# Altium schematic sheet ISL6236A_DEMO.SchDoc (continued)

## Library data of the parts
C1 = CC1206KKX5R9BB475: Ceramic Capacitor, Ceramic, 50V, 10% +Tol, 10% -Tol, X5R, 15% TC, 4.7uF, Surface Mount, 1206; Manufacturer: Yageo Group; Value: 4.7µF; Case Code (Imperial): 1206; Case Code (Metric): 3216; Case/Package: 1206; Category: Ceramic Capacitors; China RoHS: Compliant; Component Kind: Standard; ComponentLink1Description: https://datasheet.octopart.com:443/CC1206KKX5R9BB475-Yageo-datasheet-170350636.pdf?src-supplier=IHS; ComponentLink1URL: https://datasheet.octopart.com:443/CC1206KKX5R9BB475-Yageo-datasheet-170350636.pdf?src-supplier=IHS; ComponentLink2Description: https://datasheet.octopart.com:443/CC1206KKX5R9BB475-Yageo-datasheet-116051370.pdf?src-supplier=Upverter; ComponentLink2URL: https://datasheet.octopart.com:443/CC1206KKX5R9BB475-Yageo-datasheet-116051370.pdf?src-supplier=Upverter; ComponentLink3Description: https://datasheet.octopart.com:443/CC1206KKX5R9BB475-Yageo-datasheet-82288757.pdf?src-supplier=Upverter; ComponentLink3URL: https://datasheet.octopart.com:443/CC1206KKX5R9BB475-Yageo-datasheet-82288757.pdf?src-supplier=Upverter; ComponentLink4Description: https://datasheet.octopart.com:443/CC1206KKX5R9BB475-Yageo-datasheet-68297942.pdf?src-supplier=Upverter; ComponentLink4URL: https://datasheet.octopart.com:443/CC1206KKX5R9BB475-Yageo-datasheet-68297942.pdf?src-supplier=Upverter; ComponentLink5Description: https://datasheet.octopart.com:443/CC1206KKX5R9BB475-Yageo-datasheet-181970657.pdf?src-supplier=Farnell; ComponentLink5URL: https://datasheet.octopart.com:443/CC1206KKX5R9BB475-Yageo-datasheet-181970657.pdf?src-supplier=Farnell; ComponentLink6Description: https://datasheet.octopart.com:443/CC1206KKX5R9BB475-Yageo-datasheet-181970654.pdf?src-supplier=Farnell; ComponentLink6URL: https://datasheet.octopart.com:443/CC1206KKX5R9BB475-Yageo-datasheet-181970654.pdf?src-supplier=Farnell; ComponentLink7Description: https://datasheet.octopart.com:443/CC1206KKX5R9BB475-Yageo-datasheet-145016144.pdf?src-supplier=ODG+(Origin+Data+Global); ComponentLink7URL: https://datasheet.octopart.com:443/CC1206KKX5R9BB475-Yageo-datasheet-145016144.pdf?src-supplier=ODG+(Origin+Data+Global); ComponentLink8Description: https://datasheet.octopart.com:443/CC1206KKX5R9BB475-Yageo-datasheet-158238148.pdf?src-supplier=Newark; ComponentLink8URL: https://datasheet.octopart.com:443/CC1206KKX5R9BB475-Yageo-datasheet-158238148.pdf?src-supplier=Newark; ComponentLink9Description: https://datasheet.octopart.com/CC1206KKX5R9BB475-Yageo-datasheet-86397281.pdf; ComponentLink9URL: https://datasheet.octopart.com/CC1206KKX5R9BB475-Yageo-datasheet-86397281.pdf; ComponentLink10Description: http://sigma.octopart.com:80/rohs_statement/Yageo-company-2.pdf?src-supplier=Compliance+Statements; ComponentLink10URL: http://sigma.octopart.com:80/rohs_statement/Yageo-company-2.pdf?src-supplier=Compliance+Statements; ComponentLink11Description: http://sigma.octopart.com:80/conflict_mineral_statement/Yageo-company-3.pdf?src-supplier=Compliance+Statements; ComponentLink11URL: http://sigma.octopart.com:80/conflict_mineral_statement/Yageo-company-3.pdf?src-supplier=Compliance+Statements; Composition: Ceramic; Dielectric: X5R; Dielectric Material: Ceramic; Halogen Free: Halogen Free; Height: 1.6mm; Lead Free: Lead Free; Length: 3.2mm; Library Name: ISL6236A_DEMO.SchLib; Lifecycle Status: Production; Manufacturer Part Number: CC1206KKX5R9BB475; Material: Ceramic; Max Operating Temperature: 85°C; Min Operating Temperature: -55°C; Mounting Technology: Surface Mount; Number of Terminals: 2; Packaging: Tape & Reel (TR); Pins: 2; Pricing: 1=0.32, 10=0.142, 50=0.142, 100=0.116, 1000=0.098, 10000=0.072 (USD); REACH SVHC: Yes; RoHS: Compliant; Schedule B: 8532240020, 8532240020|8532240020|8532240020|8532240020|8532240020; Stock: 5467; Supplier: Mouser; Supplier Part Number: 603-CC206KKX5R9BB475; Termination: SMD/SMT; Thickness: 1.8034mm; Tolerance: 10%; Voltage Rating: 50V; Voltage Rating (DC): 50V; Weight: 16.187578mg; Width: 1.6mm
C2-C4 = 08055C105K4Z2A: Cap Ceramic 1uF 50V X7R 10% Pad SMD 0805 FlexiTerm 125C Automotive T/R; Manufacturer: KYOCERA AVX; Value: 1µF; Case/Package: 0805; Category: Ceramic Capacitors; China RoHS: Compliant; Component Kind: Standard; ComponentLink1Description: https://datasheet.octopart.com:443/08055C105K4Z2A-KYOCERA-AVX-datasheet-172544266.pdf?src-supplier=IHS; ComponentLink1URL: https://datasheet.octopart.com:443/08055C105K4Z2A-KYOCERA-AVX-datasheet-172544266.pdf?src-supplier=IHS; ComponentLink2Description: https://sigma.octopart.com:443/147443767/technical_drawing/AVX-08055C105K4Z2A.pdf?src-supplier=Upverter; ComponentLink2URL: https://sigma.octopart.com:443/147443767/technical_drawing/AVX-08055C105K4Z2A.pdf?src-supplier=Upverter; ComponentLink3Description: https://datasheet.octopart.com:443/08055C105K4Z2A-KYOCERA-AVX-datasheet-140258758.pdf?src-supplier=Farnell; ComponentLink3URL: https://datasheet.octopart.com:443/08055C105K4Z2A-KYOCERA-AVX-datasheet-140258758.pdf?src-supplier=Farnell; ComponentLink4Description: https://datasheet.octopart.com:443/08055C105K4Z2A-AVX-datasheet-78690206.pdf?src-supplier=element14+APAC; ComponentLink4URL: https://datasheet.octopart.com:443/08055C105K4Z2A-AVX-datasheet-78690206.pdf?src-supplier=element14+APAC; ComponentLink5Description: https://datasheet.octopart.com:443/08055C105K4Z2A-AVX-datasheet-62336669.pdf?src-supplier=element14+APAC; ComponentLink5URL: https://datasheet.octopart.com:443/08055C105K4Z2A-AVX-datasheet-62336669.pdf?src-supplier=element14+APAC; ComponentLink6Description: https://datasheet.octopart.com:443/08055C105K4Z2A-AVX-datasheet-42544723.pdf?src-supplier=element14+APAC; ComponentLink6URL: https://datasheet.octopart.com:443/08055C105K4Z2A-AVX-datasheet-42544723.pdf?src-supplier=element14+APAC; ComponentLink7Description: https://datasheet.octopart.com:443/08055C105K4Z2A-AVX-datasheet-158242088.pdf?src-supplier=Amar+Radio+Corporation; ComponentLink7URL: https://datasheet.octopart.com:443/08055C105K4Z2A-AVX-datasheet-158242088.pdf?src-supplier=Amar+Radio+Corporation; ComponentLink8Description: https://datasheet.octopart.com:443/08055C105K4Z2A-KYOCERA-AVX-datasheet-50865432.pdf?src-supplier=Future+Electronics; ComponentLink8URL: https://datasheet.octopart.com:443/08055C105K4Z2A-KYOCERA-AVX-datasheet-50865432.pdf?src-supplier=Future+Electronics; ComponentLink9Description: https://datasheet.octopart.com/08055C105K4Z2A-KYOCERA-AVX-datasheet-13516023.pdf; ComponentLink9URL: https://datasheet.octopart.com/08055C105K4Z2A-KYOCERA-AVX-datasheet-13516023.pdf; ComponentLink10Description: http://sigma.octopart.com:80/reach_statement/AVX-company-19.pdf?src-supplier=Compliance+Statements; ComponentLink10URL: http://sigma.octopart.com:80/reach_statement/AVX-company-19.pdf?src-supplier=Compliance+Statements; ComponentLink11Description: http://sigma.octopart.com:80/conflict_mineral_statement/AVX-company-34.pdf?src-supplier=Compliance+Statements; ComponentLink11URL: http://sigma.octopart.com:80/conflict_mineral_statement/AVX-company-34.pdf?src-supplier=Compliance+Statements; Contact Plating: Tin; Dielectric: X7R; Dielectric Material: Ceramic; Height: 1.4mm; Length: 2.01mm; Library Name: ISL6236A_DEMO.SchLib; Lifecycle Status: Production; Manufacturer Part Number: 08055C105K4Z2A; Material: Ceramic; Max Operating Temperature: 125°C; Min Operating Temperature: -55°C; Mounting Technology: Surface Mount; Number of Terminals: 2; Pricing: 1=0.33, 10=0.144, 50=0.144, 100=0.128, 1000=0.092, 10000=0.067 (USD); REACH SVHC: Yes; RoHS: Compliant; Schedule B: 8532240020, 8532240020|8532240020|8532240020|8532240020|8532240020|8532240020|8532240020|8532240020|8532240020|8532240020|8532240020|8532240020|8532240020|8532240020|8532240020|8532240020|8532240020|8532240020|8532240020|8532240020|8532240020|8532240020|8532240020|85; Stock: 12042; Supplier: Mouser; Supplier Part Number: 581-08055C105K4Z2A; Termination: Wraparound; Tolerance: 10%; Voltage Rating (DC): 50V; Width: 1.25mm
  C3: Voltage Rating: 50V
C5 C6 = C3225X5R1H106K250AB: 50V 10uF X5R 卤10% 1210 Multilayer Ceramic Capacitors MLCC - SMD/SMT ROHS; Manufacturer: TDK; Manufacturer Lifecycle Status: PRODUCTION; Tolerance: 10%; Value: 10µF; Case Code (Imperial): 1210; Case Code (Metric): 3225; Case/Package: 1210; Category: Ceramic Capacitors; China RoHS: Compliant; Component Kind: Standard; ComponentLink1Description: https://datasheet.octopart.com:443/C3225X5R1H106K250AB-TDK-datasheet-175423548.pdf?src-supplier=TME; ComponentLink1URL: https://datasheet.octopart.com:443/C3225X5R1H106K250AB-TDK-datasheet-175423548.pdf?src-supplier=TME; ComponentLink2Description: https://datasheet.octopart.com:443/C3225X5R1H106K250AB-TDK-datasheet-182135025.pdf?src-supplier=TME; ComponentLink2URL: https://datasheet.octopart.com:443/C3225X5R1H106K250AB-TDK-datasheet-182135025.pdf?src-supplier=TME; ComponentLink3Description: https://datasheet.octopart.com:443/C3225X5R1H106K250AB-TDK-datasheet-159617022.pdf?src-supplier=TME; ComponentLink3URL: https://datasheet.octopart.com:443/C3225X5R1H106K250AB-TDK-datasheet-159617022.pdf?src-supplier=TME; ComponentLink4Description: https://sigma.octopart.com:443/138898468/technical_drawing/TDK-C3225X5R1H106K250AB.pdf?src-supplier=Upverter; ComponentLink4URL: https://sigma.octopart.com:443/138898468/technical_drawing/TDK-C3225X5R1H106K250AB.pdf?src-supplier=Upverter; ComponentLink5Description: https://datasheet.octopart.com:443/C3225X5R1H106K250AB-TDK-datasheet-151546523.pdf?src-supplier=ODG+(Origin+Data+Global); ComponentLink5URL: https://datasheet.octopart.com:443/C3225X5R1H106K250AB-TDK-datasheet-151546523.pdf?src-supplier=ODG+(Origin+Data+Global); ComponentLink6Description: https://datasheet.octopart.com:443/C3225X5R1H106K250AB-TDK-datasheet-82475248.pdf?src-supplier=Farnell; ComponentLink6URL: https://datasheet.octopart.com:443/C3225X5R1H106K250AB-TDK-datasheet-82475248.pdf?src-supplier=Farnell; ComponentLink7Description: https://datasheet.octopart.com/C3225X5R1H106K250AB-TDK-datasheet-41194876.pdf; ComponentLink7URL: https://datasheet.octopart.com/C3225X5R1H106K250AB-TDK-datasheet-41194876.pdf; ComponentLink8Description: https://datasheet.octopart.com:443/C3225X5R1H106K250AB-TDK-datasheet-25352837.pdf?src-supplier=Future+Electronics; ComponentLink8URL: https://datasheet.octopart.com:443/C3225X5R1H106K250AB-TDK-datasheet-25352837.pdf?src-supplier=Future+Electronics; ComponentLink9Description: https://datasheet.octopart.com:443/C3225X5R1H106K250AB-TDK-datasheet-23766614.pdf?src-supplier=Future+Electronics; ComponentLink9URL: https://datasheet.octopart.com:443/C3225X5R1H106K250AB-TDK-datasheet-23766614.pdf?src-supplier=Future+Electronics; ComponentLink10Description: https://datasheet.octopart.com:443/C3225X5R1H106K250AB-TDK-datasheet-10921857.pdf?src-supplier=Newark; ComponentLink10URL: https://datasheet.octopart.com:443/C3225X5R1H106K250AB-TDK-datasheet-10921857.pdf?src-supplier=Newark; Composition: Ceramic; Depth: 2.5mm; Dielectric: X5R; Dielectric Material: Ceramic; Height: 2.5mm; Lead Free: Lead Free; Length: 3.2mm; Library Name: ISL6236A_DEMO.SchLib; Lifecycle Status: Production; Manufacturer Part Number: C3225X5R1H106K250AB; Material: Ceramic; Max Length: 3.6mm; Max Operating Temperature: 85°C; Max Width: 2.8mm; Min Length: 2.8mm; Min Operating Temperature: -55°C; Min Width: 2.2mm; Mounting Technology: Surface Mount; Number of Terminals: 2; Packaging: Digi-Reel®; Pricing: 1=0.94, 10=0.421, 50=0.421, 100=0.395, 1000=0.297, 10000=0.286 (USD); REACH SVHC: Yes; RoHS: Compliant; Schedule B: 8532240020, 8532240020|8532240020|8532240020|8532240020|8532240020; Stock: 64452; Supplier: Mouser; Supplier Part Number: 810-C3225X5R1H106K; Termination: SMD/SMT; Voltage Rating: 50V; Voltage Rating (DC): 50V; Weight: 15.989131mg; Width: 2.5mm
C7 C12 = 08055C104JAT2A: 0805 100nF 50 V ±5 % Tolerance X7R Surface Mount Multilayer Ceramic Capacitor; Manufacturer: KYOCERA AVX; Dissipation Factor: 2.5%; Insulation Resistance: 100GΩ; Tolerance: 5%; Voltage: 50V; Case Code (Imperial): 0805; Case/Package: 0805; Category: Ceramic Capacitors; China RoHS: Compliant; Component Kind: Standard; ComponentLink1Description: https://datasheet.octopart.com:443/08055C104JAT2A-KYOCERA-AVX-datasheet-170560559.pdf?src-supplier=IHS; ComponentLink1URL: https://datasheet.octopart.com:443/08055C104JAT2A-KYOCERA-AVX-datasheet-170560559.pdf?src-supplier=IHS; ComponentLink2Description: https://sigma.octopart.com:443/147443767/technical_drawing/AVX-08055C104JAT2A.pdf?src-supplier=Upverter; ComponentLink2URL: https://sigma.octopart.com:443/147443767/technical_drawing/AVX-08055C104JAT2A.pdf?src-supplier=Upverter; ComponentLink3Description: https://datasheet.octopart.com:443/08055C104JAT2A-AVX-datasheet-12509786.pdf?src-supplier=Farnell; ComponentLink3URL: https://datasheet.octopart.com:443/08055C104JAT2A-AVX-datasheet-12509786.pdf?src-supplier=Farnell; ComponentLink4Description: https://datasheet.octopart.com:443/08055C104JAT2A-AVX-datasheet-12587061.pdf?src-supplier=Farnell; ComponentLink4URL: https://datasheet.octopart.com:443/08055C104JAT2A-AVX-datasheet-12587061.pdf?src-supplier=Farnell; ComponentLink5Description: https://datasheet.octopart.com:443/08055C104JAT2A-AVX-datasheet-8113618.pdf?src-supplier=Newark; ComponentLink5URL: https://datasheet.octopart.com:443/08055C104JAT2A-AVX-datasheet-8113618.pdf?src-supplier=Newark; ComponentLink6Description: https://datasheet.octopart.com:443/08055C104JAT2A-KYOCERA-AVX-datasheet-158983632.pdf?src-supplier=Newark; ComponentLink6URL: https://datasheet.octopart.com:443/08055C104JAT2A-KYOCERA-AVX-datasheet-158983632.pdf?src-supplier=Newark; ComponentLink7Description: https://datasheet.octopart.com:443/08055C104JAT2A-AVX-datasheet-78690206.pdf?src-supplier=Newark; ComponentLink7URL: https://datasheet.octopart.com:443/08055C104JAT2A-AVX-datasheet-78690206.pdf?src-supplier=Newark; ComponentLink8Description: https://datasheet.octopart.com:443/08055C104JAT2A-KYOCERA-AVX-datasheet-65931687.pdf?src-supplier=Newark; ComponentLink8URL: https://datasheet.octopart.com:443/08055C104JAT2A-KYOCERA-AVX-datasheet-65931687.pdf?src-supplier=Newark; ComponentLink9Description: https://datasheet.octopart.com:443/08055C-104JAT2A-AVX-datasheet-26446220.pdf?src-supplier=Newark; ComponentLink9URL: https://datasheet.octopart.com:443/08055C-104JAT2A-AVX-datasheet-26446220.pdf?src-supplier=Newark; ComponentLink10Description: https://datasheet.octopart.com:443/08055C104JAT2A-AVX-datasheet-5313617.pdf?src-supplier=Newark; ComponentLink10URL: https://datasheet.octopart.com:443/08055C104JAT2A-AVX-datasheet-5313617.pdf?src-supplier=Newark; ComponentLink11Description: https://datasheet.octopart.com:443/08055C104JAT2A-AVX-datasheet-167033.pdf?src-supplier=Newark; ComponentLink11URL: https://datasheet.octopart.com:443/08055C104JAT2A-AVX-datasheet-167033.pdf?src-supplier=Newark; ComponentLink12Description: https://datasheet.octopart.com:443/08055C104JAT2A-AVX---Kyocera-datasheet-8446237.pdf?src-supplier=TME; ComponentLink12URL: https://datasheet.octopart.com:443/08055C104JAT2A-AVX---Kyocera-datasheet-8446237.pdf?src-supplier=TME; ComponentLink13Description: https://datasheet.octopart.com:443/08055C104JAT2A-KYOCERA-AVX-datasheet-156093841.pdf?src-supplier=TME; ComponentLink13URL: https://datasheet.octopart.com:443/08055C104JAT2A-KYOCERA-AVX-datasheet-156093841.pdf?src-supplier=TME; ComponentLink14Description: https://datasheet.octopart.com:443/08055C104JAT2A-AVX-datasheet-166051239.pdf?src-supplier=Amar+Radio+Corporation; ComponentLink14URL: https://datasheet.octopart.com:443/08055C104JAT2A-AVX-datasheet-166051239.pdf?src-supplier=Amar+Radio+Corporation; ComponentLink15Description: https://datasheet.octopart.com:443/08055C104JAT2A-AVX-datasheet-50865064.pdf?src-supplier=Future+Electronics; ComponentLink15URL: https://datasheet.octopart.com:443/08055C104JAT2A-AVX-datasheet-50865064.pdf?src-supplier=Future+Electronics; ComponentLink16Description: https://datasheet.octopart.com:443/08055C104JAT2A-KYOCERA-AVX-datasheet-50865067.pdf?src-supplier=Future+Electronics; ComponentLink16URL: https://datasheet.octopart.com:443/08055C104JAT2A-KYOCERA-AVX-datasheet-50865067.pdf?src-supplier=Future+Electronics; ComponentLink17Description: https://datasheet.octopart.com:443/08055C104JAT2A-AVX-datasheet-12340990.pdf?src-supplier=Future+Electronics; ComponentLink17URL: https://datasheet.octopart.com:443/08055C104JAT2A-AVX-datasheet-12340990.pdf?src-supplier=Future+Electronics; ComponentLink18Description: https://datasheet.octopart.com:443/08055C104JAT2A-AVX-datasheet-8448153.pdf?src-supplier=Future+Electronics; ComponentLink18URL: https://datasheet.octopart.com:443/08055C104JAT2A-AVX-datasheet-8448153.pdf?src-supplier=Future+Electronics; ComponentLink19Description: https://datasheet.octopart.com:443/08055C104JAT2A-AVX-datasheet-5314571.pdf?src-supplier=Future+Electronics; ComponentLink19URL: https://datasheet.octopart.com:443/08055C104JAT2A-AVX-datasheet-5314571.pdf?src-supplier=Future+Electronics; ComponentLink20Description: https://datasheet.octopart.com/08055C104JAT2A-AVX---Kyocera-datasheet-13516023.pdf; ComponentLink20URL: https://datasheet.octopart.com/08055C104JAT2A-AVX---Kyocera-datasheet-13516023.pdf; ComponentLink21Description: https://datasheet.octopart.com/Commercial-Surface-Mount-Chips---X7R-Dielectric-AVX-datasheet-family-100.pdf; ComponentLink21URL: https://datasheet.octopart.com/Commercial-Surface-Mount-Chips---X7R-Dielectric-AVX-datasheet-family-100.pdf; ComponentLink22Description: https://datasheet.octopart.com:443/08055C104JAT2A-AVX-datasheet-5377487.pdf?src-supplier=Garrett; ComponentLink22URL: https://datasheet.octopart.com:443/08055C104JAT2A-AVX-datasheet-5377487.pdf?src-supplier=Garrett; ComponentLink23Description: https://datasheet.octopart.com:443/08055C104JAT2A-AVX-datasheet-5383.pdf?src-supplier=Garrett; ComponentLink23URL: https://datasheet.octopart.com:443/08055C104JAT2A-AVX-datasheet-5383.pdf?src-supplier=Garrett; ComponentLink24Description: https://datasheet.octopart.com:443/08055C104JAT2A-AVX-datasheet-148183.pdf?src-supplier=Garrett; ComponentLink24URL: https://datasheet.octopart.com:443/08055C104JAT2A-AVX-datasheet-148183.pdf?src-supplier=Garrett; ComponentLink25Description: https://datasheet.octopart.com:443/08055C104JAT2A-AVX-datasheet-17703057.pdf?src-supplier=Jameco; ComponentLink25URL: https://datasheet.octopart.com:443/08055C104JAT2A-AVX-datasheet-17703057.pdf?src-supplier=Jameco; ComponentLink26Description: https://datasheet.octopart.com:443/08055C104JAT2A-AVX-datasheet-12134.pdf?src-supplier=DigiKey; ComponentLink26URL: https://datasheet.octopart.com:443/08055C104JAT2A-AVX-datasheet-12134.pdf?src-supplier=DigiKey; ComponentLink27Description: https://datasheet.octopart.com:443/08055C104JAT2A-AVX-datasheet-4352.pdf?src-supplier=RS+(Formerly+Allied+Electronics); ComponentLink27URL: https://datasheet.octopart.com:443/08055C104JAT2A-AVX-datasheet-4352.pdf?src-supplier=RS+(Formerly+Allied+Electronics); ComponentLink28Description: https://datasheet.octopart.com:443/08055C104JAT2A-AVX-datasheet-111406.pdf?src-supplier=Sierra+IC; ComponentLink28URL: https://datasheet.octopart.com:443/08055C104JAT2A-AVX-datasheet-111406.pdf?src-supplier=Sierra+IC; ComponentLink29Description: http://sigma.octopart.com:80/reach_statement/AVX-company-19.pdf?src-supplier=Compliance+Statements; ComponentLink29URL: http://sigma.octopart.com:80/reach_statement/AVX-company-19.pdf?src-supplier=Compliance+Statements; ComponentLink30Description: http://sigma.octopart.com:80/conflict_mineral_statement/AVX-company-34.pdf?src-supplier=Compliance+Statements; ComponentLink30URL: http://sigma.octopart.com:80/conflict_mineral_statement/AVX-company-34.pdf?src-supplier=Compliance+Statements; Contact Plating: Tin; Depth: 1.25mm; Dielectric: X7R; Dielectric Material: Ceramic; Height: 940µm; Lead Free: Lead Free; Length: 2.01mm; Library Name: ISL6236A_DEMO.SchLib; Lifecycle Status: Production; Manufacturer Part Number: 08055C104JAT2A; Material: Ceramic; Max Operating Temperature: 125°C; Min Operating Temperature: -55°C; Mounting Technology: Surface Mount; Number of Terminals: 2; Packaging: Cut Tape; Pins: 2; Pricing: 1=0.11, 10=0.067, 50=0.067, 100=0.054, 1000=0.048, 10000=0.022 (USD); Radiation Hardening: No; REACH SVHC: Yes; RoHS: Compliant; Schedule B: 8532240020; Stock: 196751; Supplier: Mouser; Supplier Part Number: 581-08055C104J; Termination: SMD/SMT; Thickness: 939.8µm; Value: 100nF; Voltage Rating: 50V; Voltage Rating (DC): 50V; Width: 1.25mm
C8 = 08055C224KAZ2A: Cap Ceramic 0.22uF 50V X7R 10% SMD 0805 FlexiTerm 125°C Embossed T/R; Manufacturer: KYOCERA AVX; Dissipation Factor: 2.5%; Case Code (Imperial): 0805; Case Code (Metric): 2012; Case/Package: 0805; Category: Ceramic Capacitors; China RoHS: Compliant; Component Kind: Standard; ComponentLink1Description: https://datasheet.octopart.com:443/08055C224KAZ2A-KYOCERA-AVX-datasheet-170560559.pdf?src-supplier=IHS; ComponentLink1URL: https://datasheet.octopart.com:443/08055C224KAZ2A-KYOCERA-AVX-datasheet-170560559.pdf?src-supplier=IHS; ComponentLink2Description: https://sigma.octopart.com:443/147443767/technical_drawing/AVX-08055C224KAZ2A.pdf?src-supplier=Upverter; ComponentLink2URL: https://sigma.octopart.com:443/147443767/technical_drawing/AVX-08055C224KAZ2A.pdf?src-supplier=Upverter; ComponentLink3Description: https://datasheet.octopart.com:443/08055C224KAZ2A-AVX-datasheet-12587061.pdf?src-supplier=Newark; ComponentLink3URL: https://datasheet.octopart.com:443/08055C224KAZ2A-AVX-datasheet-12587061.pdf?src-supplier=Newark; ComponentLink4Description: https://datasheet.octopart.com:443/08055C224KAZ2A-AVX-datasheet-12509786.pdf?src-supplier=Newark; ComponentLink4URL: https://datasheet.octopart.com:443/08055C224KAZ2A-AVX-datasheet-12509786.pdf?src-supplier=Newark; ComponentLink5Description: https://datasheet.octopart.com:443/08055C224KAZ2A-KYOCERA-AVX-datasheet-158983632.pdf?src-supplier=Newark; ComponentLink5URL: https://datasheet.octopart.com:443/08055C224KAZ2A-KYOCERA-AVX-datasheet-158983632.pdf?src-supplier=Newark; ComponentLink6Description: https://datasheet.octopart.com:443/08055C224KAZ2A-AVX-datasheet-78690206.pdf?src-supplier=Newark; ComponentLink6URL: https://datasheet.octopart.com:443/08055C224KAZ2A-AVX-datasheet-78690206.pdf?src-supplier=Newark; ComponentLink7Description: https://datasheet.octopart.com:443/08055C224KAZ2A-KYOCERA-AVX-datasheet-65931687.pdf?src-supplier=Newark; ComponentLink7URL: https://datasheet.octopart.com:443/08055C224KAZ2A-KYOCERA-AVX-datasheet-65931687.pdf?src-supplier=Newark; ComponentLink8Description: https://datasheet.octopart.com:443/08055C224KAZ2A-AVX-datasheet-8113618.pdf?src-supplier=Newark; ComponentLink8URL: https://datasheet.octopart.com:443/08055C224KAZ2A-AVX-datasheet-8113618.pdf?src-supplier=Newark; ComponentLink9Description: https://datasheet.octopart.com:443/08055C224KAZ2A-AVX-datasheet-5313617.pdf?src-supplier=Newark; ComponentLink9URL: https://datasheet.octopart.com:443/08055C224KAZ2A-AVX-datasheet-5313617.pdf?src-supplier=Newark; ComponentLink10Description: https://datasheet.octopart.com:443/08055C224KAZ2A-AVX-datasheet-167033.pdf?src-supplier=Newark; ComponentLink10URL: https://datasheet.octopart.com:443/08055C224KAZ2A-AVX-datasheet-167033.pdf?src-supplier=Newark; ComponentLink11Description: https://datasheet.octopart.com:443/08055C224KAZ2A.-KYOCERA-AVX-datasheet-184397820.pdf?src-supplier=Newark; ComponentLink11URL: https://datasheet.octopart.com:443/08055C224KAZ2A.-KYOCERA-AVX-datasheet-184397820.pdf?src-supplier=Newark; ComponentLink12Description: https://datasheet.octopart.com:443/08055C224KAZ2A-KYOCERA-AVX-datasheet-50865432.pdf?src-supplier=TME; ComponentLink12URL: https://datasheet.octopart.com:443/08055C224KAZ2A-KYOCERA-AVX-datasheet-50865432.pdf?src-supplier=TME; ComponentLink13Description: https://datasheet.octopart.com:443/08055C224KAZ2A-KYOCERA-AVX-datasheet-156093841.pdf?src-supplier=TME; ComponentLink13URL: https://datasheet.octopart.com:443/08055C224KAZ2A-KYOCERA-AVX-datasheet-156093841.pdf?src-supplier=TME; ComponentLink14Description: https://datasheet.octopart.com/08055C224KAZ2A-AVX---Kyocera-datasheet-13516023.pdf; ComponentLink14URL: https://datasheet.octopart.com/08055C224KAZ2A-AVX---Kyocera-datasheet-13516023.pdf; ComponentLink15Description: https://datasheet.octopart.com/Commercial-Surface-Mount-Chips---X7R-Dielectric-AVX-datasheet-family-100.pdf; ComponentLink15URL: https://datasheet.octopart.com/Commercial-Surface-Mount-Chips---X7R-Dielectric-AVX-datasheet-family-100.pdf; ComponentLink16Description: https://datasheet.octopart.com:443/08055C224KAZ2A-AVX-datasheet-8458193.pdf?src-supplier=Arrow+Electronics; ComponentLink16URL: https://datasheet.octopart.com:443/08055C224KAZ2A-AVX-datasheet-8458193.pdf?src-supplier=Arrow+Electronics; ComponentLink17Description: https://datasheet.octopart.com:443/08055C224KAZ2A-AVX-datasheet-4504.pdf?src-supplier=DigiKey; ComponentLink17URL: https://datasheet.octopart.com:443/08055C224KAZ2A-AVX-datasheet-4504.pdf?src-supplier=DigiKey; ComponentLink18Description: http://sigma.octopart.com:80/reach_statement/AVX-company-19.pdf?src-supplier=Compliance+Statements; ComponentLink18URL: http://sigma.octopart.com:80/reach_statement/AVX-company-19.pdf?src-supplier=Compliance+Statements; ComponentLink19Description: http://sigma.octopart.com:80/conflict_mineral_statement/AVX-company-34.pdf?src-supplier=Compliance+Statements; ComponentLink19URL: http://sigma.octopart.com:80/conflict_mineral_statement/AVX-company-34.pdf?src-supplier=Compliance+Statements; Contact Plating: Tin; Depth: 1.25mm; Dielectric: X7R; Dielectric Material: Ceramic; Features: Soft Termination; Height: 1.4mm; Lead Free: Lead Free; Length: 2.01mm; Library Name: ISL6236A_DEMO.SchLib; Lifecycle Status: Production; Manufacturer Part Number: 08055C224KAZ2A; Material: Ceramic; Max Operating Temperature: 125°C; Min Operating Temperature: -55°C; Mounting Technology: Surface Mount; Number of Terminals: 2; Packaging: Cut Tape; Pins: 2; Pricing: 1=0.21, 10=0.12, 50=0.12, 100=0.072, 1000=0.055, 10000=0.04 (USD); Radiation Hardening: No; REACH SVHC: Yes; RoHS: Compliant; Schedule B: 8532240020, 8532240020|8532240020|8532240020|8532240020|8532240020|8532240020|8532240020|8532240020|8532240020|8532240020|8532240020|8532240020|8532240020|8532240020|8532240020|8532240020|8532240020|8532240020|8532240020|8532240020|8532240020|8532240020|8532240020|85; Stock: 8093; Supplier: Mouser; Supplier Part Number: 581-08055C224KAZ2A; Termination: SMD/SMT; Thickness: 1.397mm; Tolerance: 10%; Value: 220nF; Voltage Rating: 50V; Voltage Rating (DC): 50V; Width: 1.25mm
C9 C10 = T520D337M006ATE009: Cap Tant Solid 330uF 6.3V D CASE 20% (7.3 X 4.3 X 2.8mm) SMD 7343-31 0.009 Ohm 105 C T/R; Manufacturer: KEMET; Dielectric Material: Tantalum; Dissipation Factor: 10%; ESR (Equivalent Series Resistance): 9mΩ; Leakage Current: 207.9µA; Life (Hours): 2000hours; Polarity: Polar; Ripple Current: 1.25A; Value: 330µF; Case Code (Imperial): 2917; Case Code (Metric): 7343; Case/Package: 2917; Category: Tantalum Capacitors; China RoHS: Compliant; Component Kind: Standard; ComponentLink1Description: https://datasheet.octopart.com:443/T520D337M006ATE009-KEMET-datasheet-182062996.pdf?src-supplier=IHS; ComponentLink1URL: https://datasheet.octopart.com:443/T520D337M006ATE009-KEMET-datasheet-182062996.pdf?src-supplier=IHS; ComponentLink2Description: https://datasheet.octopart.com:443/T520D337M006ATE009-KEMET-datasheet-101579113.pdf?src-supplier=Farnell; ComponentLink2URL: https://datasheet.octopart.com:443/T520D337M006ATE009-KEMET-datasheet-101579113.pdf?src-supplier=Farnell; ComponentLink3Description: https://datasheet.octopart.com:443/T520D337M006ATE009-KEMET-datasheet-181973551.pdf?src-supplier=Farnell; ComponentLink3URL: https://datasheet.octopart.com:443/T520D337M006ATE009-KEMET-datasheet-181973551.pdf?src-supplier=Farnell; ComponentLink4Description: https://datasheet.octopart.com:443/T520D337M006ATE009-Kemet-datasheet-21202729.pdf?src-supplier=element14+APAC; ComponentLink4URL: https://datasheet.octopart.com:443/T520D337M006ATE009-Kemet-datasheet-21202729.pdf?src-supplier=element14+APAC; ComponentLink5Description: https://datasheet.octopart.com:443/T520D337M006ATE009-Kemet-datasheet-62289769.pdf?src-supplier=element14+APAC; ComponentLink5URL: https://datasheet.octopart.com:443/T520D337M006ATE009-Kemet-datasheet-62289769.pdf?src-supplier=element14+APAC; ComponentLink6Description: https://datasheet.octopart.com:443/T520D337M006ATE009-KEMET-datasheet-86454297.pdf?src-supplier=element14+APAC; ComponentLink6URL: https://datasheet.octopart.com:443/T520D337M006ATE009-KEMET-datasheet-86454297.pdf?src-supplier=element14+APAC; ComponentLink7Description: https://datasheet.octopart.com:443/T520D337M006ATE009-KEMET-datasheet-74836360.pdf?src-supplier=element14+APAC; ComponentLink7URL: https://datasheet.octopart.com:443/T520D337M006ATE009-KEMET-datasheet-74836360.pdf?src-supplier=element14+APAC; ComponentLink8Description: https://datasheet.octopart.com:443/T520D337M006ATE009-KEMET-datasheet-142167462.pdf?src-supplier=Electro+Sonic; ComponentLink8URL: https://datasheet.octopart.com:443/T520D337M006ATE009-KEMET-datasheet-142167462.pdf?src-supplier=Electro+Sonic; ComponentLink9Description: https://datasheet.octopart.com:443/T520D337M006ATE009-KEMET-datasheet-168262807.pdf?src-supplier=Newark; ComponentLink9URL: https://datasheet.octopart.com:443/T520D337M006ATE009-KEMET-datasheet-168262807.pdf?src-supplier=Newark; ComponentLink10Description: https://datasheet.octopart.com:443/T520D337M006ATE009-Kemet-datasheet-8837283.pdf?src-supplier=Newark; ComponentLink10URL: https://datasheet.octopart.com:443/T520D337M006ATE009-Kemet-datasheet-8837283.pdf?src-supplier=Newark; ComponentLink11Description: https://datasheet.octopart.com:443/T520D337M006ATE009-Kemet-datasheet-8325930.pdf?src-supplier=Newark; ComponentLink11URL: https://datasheet.octopart.com:443/T520D337M006ATE009-Kemet-datasheet-8325930.pdf?src-supplier=Newark; ComponentLink12Description: https://datasheet.octopart.com:443/T520D337M006ATE009-KEMET-datasheet-140425696.pdf?src-supplier=TME; ComponentLink12URL: https://datasheet.octopart.com:443/T520D337M006ATE009-KEMET-datasheet-140425696.pdf?src-supplier=TME; Depth: 4.3mm; Features: General Purpose; Height: 2.8mm; Height - Seated (Max): 3.0988mm; Length: 7.3mm; Library Name: ISL6236A_DEMO.SchLib; Lifecycle Status: Production; Manufacturer Part Number: T520D337M006ATE009; Max Operating Temperature: 105°C; Min Operating Temperature: -55°C; Mounting Technology: Surface Mount; Number of Terminals: 2; Packaging: Tape and Reel; Pins: 2; Pricing: 1=1.97, 10=1.08, 50=1.03, 100=0.977 (EUR); Radiation Hardening: No; REACH SVHC: Yes; RoHS: Compliant; Schedule B: 8532210050, 8532210050|8532210050|8532210050|8532210050|8532210050; Stock: 600; Supplier: Farnell; Supplier Part Number: 2535309; Termination: SMD/SMT; Tolerance: 20%; Voltage Rating: 6.3V; Voltage Rating (DC): 6.3V; Width: 4.3mm
C11 = MSAYU219SSD103KTNA01: Ceramic Capacitor, Multilayer, Ceramic, 50V, 10% +Tol, 10% -Tol, 0.01uF, Surface Mount, 0805; Manufacturer: Taiyo Yuden; Category: Ceramic Capacitors; Component Kind: Standard; ComponentLink1Description: https://datasheet.octopart.com:443/MSAYU219SSD103KTNA01-Taiyo-Yuden-datasheet-182126740.pdf?src-supplier=IHS; ComponentLink1URL: https://datasheet.octopart.com:443/MSAYU219SSD103KTNA01-Taiyo-Yuden-datasheet-182126740.pdf?src-supplier=IHS; Dielectric Material: Ceramic; Height: 850µm; Length: 2mm; Library Name: ISL6236A_DEMO.SchLib; Lifecycle Status: Production; Manufacturer Part Number: MSAYU219SSD103KTNA01; Max Operating Temperature: 125°C; Min Operating Temperature: -55°C; Mounting Technology: Surface Mount; Number of Terminals: 2; Pricing: 1=0.33, 10=0.197, 50=0.197, 100=0.129, 1000=0.089, 10000=0.066 (USD); REACH SVHC: Yes; RoHS: Compliant; Supplier: Mouser; Supplier Part Number: 963-MSAYU219SSD103KT; Value: 10nF; Voltage Rating (DC): 50V; Width: 1.25mm
J1-J6 = PAD_WITH_HOLE_4MMx1MM5: pad with a hole 4mm x 1.5mm; Component Kind: Standard; Library Name: ISL6236A_DEMO.SchLib
L1 = DR125-3R3-R: Inductor Power Shielded Drum Core 3.3uH 20% 100KHz Ferrite 9.26A 6.3mOhm DCR T/R; Manufacturer: Eaton; DC Resistance (DCR): 6.3mΩ; Number of Circuits: 1; Saturation Current: 12.7A; Shielding: Yes; Value: 3.3µH; Case/Package: 0125; Category: Fixed Inductors; Component Kind: Standard; ComponentLink1Description: https://datasheet.octopart.com:443/DR125-3R3-R-Eaton-datasheet-129252529.pdf?src-supplier=Electro+Sonic; ComponentLink1URL: https://datasheet.octopart.com:443/DR125-3R3-R-Eaton-datasheet-129252529.pdf?src-supplier=Electro+Sonic; ComponentLink2Description: https://datasheet.octopart.com:443/DR125-3R3-R-Eaton-datasheet-41175520.pdf?src-supplier=Master+Electronics; ComponentLink2URL: https://datasheet.octopart.com:443/DR125-3R3-R-Eaton-datasheet-41175520.pdf?src-supplier=Master+Electronics; ComponentLink3Description: https://datasheet.octopart.com:443/DR125-3R3-R-Coiltronics-datasheet-8612520.pdf?src-supplier=IHS; ComponentLink3URL: https://datasheet.octopart.com:443/DR125-3R3-R-Coiltronics-datasheet-8612520.pdf?src-supplier=IHS; ComponentLink4Description: https://datasheet.octopart.com:443/DR125-3R3-R-Eaton-datasheet-552538.pdf?src-supplier=Newark; ComponentLink4URL: https://datasheet.octopart.com:443/DR125-3R3-R-Eaton-datasheet-552538.pdf?src-supplier=Newark; ComponentLink5Description: https://datasheet.octopart.com:443/DR125-3R3-R-Coiltronics-datasheet-3395.pdf?src-supplier=Factory+Futures; ComponentLink5URL: https://datasheet.octopart.com:443/DR125-3R3-R-Coiltronics-datasheet-3395.pdf?src-supplier=Factory+Futures; ComponentLink6Description: https://datasheet.octopart.com:443/DR125-3R3-R-Eaton-datasheet-37490916.pdf?src-supplier=RS+(Formerly+Allied+Electronics); ComponentLink6URL: https://datasheet.octopart.com:443/DR125-3R3-R-Eaton-datasheet-37490916.pdf?src-supplier=RS+(Formerly+Allied+Electronics); ComponentLink7Description: https://datasheet.octopart.com:443/DR125-3R3-R-Coiltronics-datasheet-119352.pdf?src-supplier=DigiKey; ComponentLink7URL: https://datasheet.octopart.com:443/DR125-3R3-R-Coiltronics-datasheet-119352.pdf?src-supplier=DigiKey; Composition: Wirewound; Core Material: Ferrite; Current Rating: 9.26A; Depth: 12.5mm; Height: 6mm; Height - Seated (Max): 5.9944mm; Inductance Tolerance: 20%; Lead Free: Lead Free; Length: 12.5mm; Library Name: ISL6236A_DEMO.SchLib; Lifecycle Status: Production; Manufacturer Part Number: DR125-3R3-R; Max Current Rating: 9.26A; Max DC Current: 9.26A; Max Operating Temperature: 125°C; Military Standard: Not; Min Operating Temperature: -40°C; Mounting Technology: Surface Mount; Number of Terminals: 2; Pins: 2; Pricing: 1=0.89, 10=0.743, 50=0.737, 100=0.625, 1000=0.572, 10000=0.472 (USD); Radiation Hardening: No; REACH SVHC: No; RMS Current (Irms): 9.26A; RoHS: Compliant; Schedule B: 8504500000, 8504500000|8504500000|8504500000|8504500000|8504500000|8504500000|8504500000|8504500000|8504500000|8504500000|8504500000|8504500000|8504500000|8504500000|8504500000|8504500000|8504500000|8504500000|8504500000|8504500000|8504500000|8504500000|8504500000|85; Self Resonant Frequency: 100kHz; Stock: 2534; Supplier: Mouser; Supplier Part Number: 704-DR125-3R3-R; Termination: SMD/SMT; Test Frequency: 100kHz; Tolerance: 20%; Weight: 4.082331g; Width: 12.5mm
L2 = DR125-2R2-R: Ind Power Shielded Wirewound 2.2uH 20% 100KHz Ferrite 10.9A T/R; Manufacturer: Eaton; DC Resistance (DCR): 4.5mΩ; Number of Circuits: 1; Saturation Current: 15A; Shielding: Yes; Value: 2.2µH; Case/Package: Nonstandard; Category: Fixed Inductors; China RoHS: Compliant; Component Kind: Standard; ComponentLink1Description: https://datasheet.octopart.com:443/DR125-2R2-R-Eaton-datasheet-129252529.pdf?src-supplier=Electro+Sonic; ComponentLink1URL: https://datasheet.octopart.com:443/DR125-2R2-R-Eaton-datasheet-129252529.pdf?src-supplier=Electro+Sonic; ComponentLink2Description: https://datasheet.octopart.com:443/DR125-2R2-R-Eaton-datasheet-41175520.pdf?src-supplier=Master+Electronics; ComponentLink2URL: https://datasheet.octopart.com:443/DR125-2R2-R-Eaton-datasheet-41175520.pdf?src-supplier=Master+Electronics; ComponentLink3Description: https://datasheet.octopart.com:443/DR125-2R2-R-Coiltronics-datasheet-8612520.pdf?src-supplier=IHS; ComponentLink3URL: https://datasheet.octopart.com:443/DR125-2R2-R-Coiltronics-datasheet-8612520.pdf?src-supplier=IHS; ComponentLink4Description: https://datasheet.octopart.com:443/DR125-2R2-R-Eaton-datasheet-552538.pdf?src-supplier=Newark; ComponentLink4URL: https://datasheet.octopart.com:443/DR125-2R2-R-Eaton-datasheet-552538.pdf?src-supplier=Newark; ComponentLink5Description: https://datasheet.octopart.com:443/DR125-2R2-R-Coiltronics-datasheet-3395.pdf?src-supplier=Factory+Futures; ComponentLink5URL: https://datasheet.octopart.com:443/DR125-2R2-R-Coiltronics-datasheet-3395.pdf?src-supplier=Factory+Futures; ComponentLink6Description: https://datasheet.octopart.com:443/DR125-2R2-R-Eaton-datasheet-37490916.pdf?src-supplier=RS+(Formerly+Allied+Electronics); ComponentLink6URL: https://datasheet.octopart.com:443/DR125-2R2-R-Eaton-datasheet-37490916.pdf?src-supplier=RS+(Formerly+Allied+Electronics); ComponentLink7Description: https://datasheet.octopart.com:443/DR125-2R2-R-Coiltronics-datasheet-119352.pdf?src-supplier=DigiKey; ComponentLink7URL: https://datasheet.octopart.com:443/DR125-2R2-R-Coiltronics-datasheet-119352.pdf?src-supplier=DigiKey; Composition: Wirewound; Core Material: Ferrite; Current Rating: 10.9A; DC Current: 10.9A; Depth: 12.5mm; Height: 6mm; Height - Seated (Max): 5.9944mm; Inductance Tolerance: 20%; Lead Free: Lead Free; Length: 12.5mm; Library Name: ISL6236A_DEMO.SchLib; Lifecycle Status: Production; Manufacturer Part Number: DR125-2R2-R; Max Current Rating: 10.9A; Max DC Current: 10.9A; Max Operating Temperature: 125°C; Military Standard: Not; Min Operating Temperature: -40°C; Mounting Technology: Surface Mount; Number of Terminals: 2; Packaging: Cut Tape; Pins: 2; Pricing: 1=0.8, 10=0.66, 50=0.66, 100=0.543, 1000=0.49, 10000=0.419 (USD); Radiation Hardening: No; REACH SVHC: No; RMS Current (Irms): 10.9A; RoHS: Compliant; Self Resonant Frequency: 100kHz; Stock: 476; Supplier: Mouser; Supplier Part Number: 704-DR125-2R2-R; Termination: SMD/SMT; Test Frequency: 100kHz; Tolerance: 20%; Weight: 4.082331g; Width: 12.5mm
Q1 Q2 = SI4816BDY-T1-GE3: Transistor MOSFET Array Dual N-CH 30V 5.8A/8.2A 8-Pin SOIC T/R; Manufacturer: Vishay; Continuous Drain Current (ID): 5.8A; Drain to Source Resistance: 18.5mΩ; Fall Time: 9ns; Gate to Source Voltage (Vgs): 20V; Max Junction Temperature (Tj): 150°C; Max Power Dissipation: 2.4W; Number of Channels: 2; Threshold Voltage: 3V; Turn-Off Delay Time: 31ns; Turn-On Delay Time: 13ns; Value: 18.5mΩ; Case/Package: SO; Category: MOSFETs; China RoHS: Compliant; Component Kind: Standard; ComponentLink1Description: https://datasheet.octopart.com:443/SI4816BDY-T1-GE3-Vishay-datasheet-181471835.pdf?src-supplier=Newark; ComponentLink1URL: https://datasheet.octopart.com:443/SI4816BDY-T1-GE3-Vishay-datasheet-181471835.pdf?src-supplier=Newark; ComponentLink2Description: https://datasheet.octopart.com:443/SI4816BDY-T1-GE3-Vishay-datasheet-62318721.pdf?src-supplier=Newark; ComponentLink2URL: https://datasheet.octopart.com:443/SI4816BDY-T1-GE3-Vishay-datasheet-62318721.pdf?src-supplier=Newark; ComponentLink3Description: https://datasheet.octopart.com:443/SI4816BDY-T1-GE3-Vishay-datasheet-41341409.pdf?src-supplier=Newark; ComponentLink3URL: https://datasheet.octopart.com:443/SI4816BDY-T1-GE3-Vishay-datasheet-41341409.pdf?src-supplier=Newark; ComponentLink4Description: https://datasheet.octopart.com:443/SI4816BDY-T1-GE3-Vishay-datasheet-11047461.pdf?src-supplier=element14+APAC; ComponentLink4URL: https://datasheet.octopart.com:443/SI4816BDY-T1-GE3-Vishay-datasheet-11047461.pdf?src-supplier=element14+APAC; ComponentLink5Description: https://datasheet.octopart.com:443/SI4816BDY-T1-GE3-Vishay-datasheet-8703106.pdf?src-supplier=element14+APAC; ComponentLink5URL: https://datasheet.octopart.com:443/SI4816BDY-T1-GE3-Vishay-datasheet-8703106.pdf?src-supplier=element14+APAC; ComponentLink6Description: https://datasheet.octopart.com:443/SI4816BDY-T1-GE3-Vishay-datasheet-8332786.pdf?src-supplier=element14+APAC; ComponentLink6URL: https://datasheet.octopart.com:443/SI4816BDY-T1-GE3-Vishay-datasheet-8332786.pdf?src-supplier=element14+APAC; ComponentLink7Description: https://datasheet.octopart.com:443/SI4816BDY-T1-GE3-Vishay-datasheet-41196056.pdf?src-supplier=element14+APAC; ComponentLink7URL: https://datasheet.octopart.com:443/SI4816BDY-T1-GE3-Vishay-datasheet-41196056.pdf?src-supplier=element14+APAC; ComponentLink8Description: https://datasheet.octopart.com:443/SI4816BDY-T1-GE3-Vishay-datasheet-181482347.pdf?src-supplier=IHS; ComponentLink8URL: https://datasheet.octopart.com:443/SI4816BDY-T1-GE3-Vishay-datasheet-181482347.pdf?src-supplier=IHS; ComponentLink9Description: https://datasheet.octopart.com:443/SI4816BDY-T1-GE3-Vishay-datasheet-141196160.pdf?src-supplier=TME; ComponentLink9URL: https://datasheet.octopart.com:443/SI4816BDY-T1-GE3-Vishay-datasheet-141196160.pdf?src-supplier=TME; ComponentLink10Description: https://datasheet.octopart.com:443/SI4816BDY-T1-GE3-Vishay-datasheet-14187004.pdf?src-supplier=iiiC; ComponentLink10URL: https://datasheet.octopart.com:443/SI4816BDY-T1-GE3-Vishay-datasheet-14187004.pdf?src-supplier=iiiC; ComponentLink11Description: https://datasheet.octopart.com:443/SI4816BDY-T1-GE3-Vishay-datasheet-11554946.pdf?src-supplier=Arrow+Electronics; ComponentLink11URL: https://datasheet.octopart.com:443/SI4816BDY-T1-GE3-Vishay-datasheet-11554946.pdf?src-supplier=Arrow+Electronics; ComponentLink12Description: http://sigma.octopart.com:80/rohs_statement/Vishay-company-15.pdf?src-supplier=Compliance+Statements; ComponentLink12URL: http://sigma.octopart.com:80/rohs_statement/Vishay-company-15.pdf?src-supplier=Compliance+Statements; Contact Plating: Tin; Drain to Source Voltage (Vdss): 30V; Height: 1.75mm; Lead Free: Lead Free; Length: 5mm; Library Name: ISL6236A_DEMO.SchLib; Lifecycle Status: Production; Manufacturer Part Number: SI4816BDY-T1-GE3; Max Operating Temperature: 150°C; Min Breakdown Voltage: 30V; Min Operating Temperature: -55°C; Mounting Technology: Surface Mount; Number of Elements: 2; Number of Terminals: 8; Packaging: Digi-Reel®; Pins: 8; Pricing: 1=2.37, 10=1.49, 50=1.49, 100=1.04, 1000=0.77, 10000=0.688 (USD); Radiation Hardening: No; Rds On Max: 18.5mΩ; REACH SVHC: Yes; Rise Time: 9ns; RoHS: Compliant; Schedule B: 8541290080; Stock: 12428; Supplier: Mouser; Supplier Part Number: 781-SI4816BDY-T1-GE3; Weight: 186.993455mg; Width: 4mm
R1 R2 R13 R15 = CR0805-J/-000ELF: Zero Ohm Resistor, Jumper, 0805 [2012 Metric], Thick Film, 125 mW, 2 A, Surface Mount Device; Manufacturer: Bourns; Max Power Dissipation: 125mW; Tolerance: 5%; Value: 0Ω; Voltage Rating: 150V; Case Code (Imperial): 0805; Case Code (Metric): 2012; Case/Package: 0805; Category: Chip SMD Resistors; China RoHS: Non-Compliant; Component Kind: Standard; ComponentLink1Description: https://datasheet.octopart.com:443/CR0805-J--000ELF-Bourns-datasheet-159578630.pdf?src-supplier=ODG+(Origin+Data+Global); ComponentLink1URL: https://datasheet.octopart.com:443/CR0805-J--000ELF-Bourns-datasheet-159578630.pdf?src-supplier=ODG+(Origin+Data+Global); ComponentLink2Description: https://datasheet.octopart.com:443/CR0805-J--000ELF-Bourns-datasheet-159777434.pdf?src-supplier=IHS; ComponentLink2URL: https://datasheet.octopart.com:443/CR0805-J--000ELF-Bourns-datasheet-159777434.pdf?src-supplier=IHS; ComponentLink3Description: https://datasheet.octopart.com:443/CR0805-J--000ELF-Bourns-datasheet-68301634.pdf?src-supplier=element14+APAC; ComponentLink3URL: https://datasheet.octopart.com:443/CR0805-J--000ELF-Bourns-datasheet-68301634.pdf?src-supplier=element14+APAC; ComponentLink4Description: https://datasheet.octopart.com:443/CR0805-J--000ELF-Bourns-datasheet-28808732.pdf?src-supplier=element14+APAC; ComponentLink4URL: https://datasheet.octopart.com:443/CR0805-J--000ELF-Bourns-datasheet-28808732.pdf?src-supplier=element14+APAC; ComponentLink5Description: https://datasheet.octopart.com/CR0805-J/-000ELF-Bourns-datasheet-5318152.pdf; ComponentLink5URL: https://datasheet.octopart.com/CR0805-J/-000ELF-Bourns-datasheet-5318152.pdf; ComponentLink6Description: https://datasheet.octopart.com:443/CR0805-J--000ELF-Bourns-datasheet-154729663.pdf?src-supplier=Electro+Sonic; ComponentLink6URL: https://datasheet.octopart.com:443/CR0805-J--000ELF-Bourns-datasheet-154729663.pdf?src-supplier=Electro+Sonic; ComponentLink7Description: https://datasheet.octopart.com:443/CR0805-J--000ELF-Bourns-datasheet-34229249.pdf?src-supplier=Electro+Sonic; ComponentLink7URL: https://datasheet.octopart.com:443/CR0805-J--000ELF-Bourns-datasheet-34229249.pdf?src-supplier=Electro+Sonic; ComponentLink8Description: https://datasheet.octopart.com:443/CR0805-J--000ELF-Bourns-datasheet-11547086.pdf?src-supplier=Electro+Sonic; ComponentLink8URL: https://datasheet.octopart.com:443/CR0805-J--000ELF-Bourns-datasheet-11547086.pdf?src-supplier=Electro+Sonic; ComponentLink9Description: https://datasheet.octopart.com:443/CR0805-J--000ELF-Bourns-datasheet-24895818.pdf?src-supplier=Farnell; ComponentLink9URL: https://datasheet.octopart.com:443/CR0805-J--000ELF-Bourns-datasheet-24895818.pdf?src-supplier=Farnell; ComponentLink10Description: https://datasheet.octopart.com:443/CR0805-J--000ELF-Bourns-datasheet-22971629.pdf?src-supplier=Farnell; ComponentLink10URL: https://datasheet.octopart.com:443/CR0805-J--000ELF-Bourns-datasheet-22971629.pdf?src-supplier=Farnell; ComponentLink11Description: https://datasheet.octopart.com:443/CR0805-J--000ELF-Bourns-datasheet-49799764.pdf?src-supplier=RS+(Formerly+Allied+Electronics); ComponentLink11URL: https://datasheet.octopart.com:443/CR0805-J--000ELF-Bourns-datasheet-49799764.pdf?src-supplier=RS+(Formerly+Allied+Electronics); ComponentLink12Description: https://datasheet.octopart.com:443/CR0805-J--000ELF-Bourns-datasheet-140567134.pdf?src-supplier=Arrow.cn; ComponentLink12URL: https://datasheet.octopart.com:443/CR0805-J--000ELF-Bourns-datasheet-140567134.pdf?src-supplier=Arrow.cn; ComponentLink13Description: https://datasheet.octopart.com:443/CR0805-J/-000ELF-Bourns-datasheet-7563738.pdf?src-supplier=Jameco; ComponentLink13URL: https://datasheet.octopart.com:443/CR0805-J/-000ELF-Bourns-datasheet-7563738.pdf?src-supplier=Jameco; ComponentLink14Description: http://sigma.octopart.com:80/conflict_mineral_statement/Bourns-company-36.pdf?src-supplier=Compliance+Statements; ComponentLink14URL: http://sigma.octopart.com:80/conflict_mineral_statement/Bourns-company-36.pdf?src-supplier=Compliance+Statements; Composition: Thick Film; Contact Plating: Tin; Depth: 1.25mm; Height: 500µm; Library Name: ISL6236A_DEMO.SchLib; Lifecycle Status: Production; Manufacturer Part Number: CR0805-J/-000ELF; Max Operating Temperature: 155°C; Military Standard: Not; Min Operating Temperature: -55°C; Mounting Technology: Surface Mount; Number of Terminals: 2; Packaging: Cut Tape; Pins: 2; Power Dissipation: 125mW; Power Rating: 125mW; Pricing: 1=0.1, 10=0.009, 50=0.009, 100=0.006, 1000=0.005, 10000=0.003 (USD); Radiation Hardening: No; REACH SVHC: Yes; RoHS: Compliant; Stock: 79177; Supplier: Mouser; Supplier Part Number: 652-CR0805-J/-000ELF; Termination: SMD/SMT; Thickness: 508µm; Voltage Rating (DC): 150V; Width: 1.25mm
R3 = ERJ-S06F10R0V: Res Thick Film 0805 10 Ohm 1% 0.125W ±100ppm/°C Molded SMD Punched Carrier T/R; Manufacturer: Panasonic; Current Rating: 2A; Power Rating: 125mW; Value: 10Ω; Case Code (Metric): 0805; Case/Package: 0805; Category: Chip SMD Resistors; Component Kind: Standard; ComponentLink1Description: https://datasheet.octopart.com:443/ERJ-S06F10R0V-Panasonic-datasheet-154766176.pdf?src-supplier=Master+Electronics; ComponentLink1URL: https://datasheet.octopart.com:443/ERJ-S06F10R0V-Panasonic-datasheet-154766176.pdf?src-supplier=Master+Electronics; ComponentLink2Description: https://datasheet.octopart.com/ERJ-S06F10R0V-Panasonic-datasheet-78375410.pdf; ComponentLink2URL: https://datasheet.octopart.com/ERJ-S06F10R0V-Panasonic-datasheet-78375410.pdf; ComponentLink3Description: https://datasheet.octopart.com/ERJ-S06F10R0V-Panasonic-datasheet-61627662.pdf; ComponentLink3URL: https://datasheet.octopart.com/ERJ-S06F10R0V-Panasonic-datasheet-61627662.pdf; ComponentLink4Description: https://datasheet.octopart.com:443/ERJ-S06F10R0V-Panasonic-datasheet-118820.pdf?src-supplier=DigiKey; ComponentLink4URL: https://datasheet.octopart.com:443/ERJ-S06F10R0V-Panasonic-datasheet-118820.pdf?src-supplier=DigiKey; ComponentLink5Description: https://datasheet.octopart.com:443/ERJ-S06F10R0V-Panasonic-datasheet-21631811.pdf?src-supplier=Electro+Sonic; ComponentLink5URL: https://datasheet.octopart.com:443/ERJ-S06F10R0V-Panasonic-datasheet-21631811.pdf?src-supplier=Electro+Sonic; ComponentLink6Description: https://datasheet.octopart.com:443/ERJ-S06F10R0V-Panasonic-datasheet-37173569.pdf?src-supplier=Electro+Sonic; ComponentLink6URL: https://datasheet.octopart.com:443/ERJ-S06F10R0V-Panasonic-datasheet-37173569.pdf?src-supplier=Electro+Sonic; Lead Free: Lead Free; Library Name: ISL6236A_DEMO.SchLib; Manufacturer Part Number: ERJ-S06F10R0V; Pricing: 1=0.26, 10=0.094, 50=0.094, 100=0.063, 1000=0.057, 10000=0.038 (USD); RoHS: Compliant; Stock: 701; Supplier: Mouser; Supplier Part Number: 667-ERJ-S06F10R0V; Temperature Coefficient: 100ppm/°C
R4 R5 = RC0805FR-101RL: Fixed Resistor, Metal Glaze/thick Film, 0.125W, 1ohm, 150V, 1% +/-Tol, 200ppm/Cel, Surface Mount, 0805; Manufacturer: Yageo Group; Power Dissipation: 125mW; Temperature Coefficient: 200ppm/°C; Value: 1Ω; Case/Package: 0805; Category: Chip SMD Resistors; China RoHS: Non-Compliant; Component Kind: Standard; ComponentLink1Description: https://datasheet.octopart.com:443/RC0805FR-101RL-Yageo-datasheet-175887523.pdf?src-supplier=Newark; ComponentLink1URL: https://datasheet.octopart.com:443/RC0805FR-101RL-Yageo-datasheet-175887523.pdf?src-supplier=Newark; ComponentLink2Description: https://datasheet.octopart.com/RC0805FR-101RL-Yageo-datasheet-73766026.pdf; ComponentLink2URL: https://datasheet.octopart.com/RC0805FR-101RL-Yageo-datasheet-73766026.pdf; ComponentLink3Description: http://sigma.octopart.com:80/conflict_mineral_statement/Yageo-company-3.pdf?src-supplier=Compliance+Statements; ComponentLink3URL: http://sigma.octopart.com:80/conflict_mineral_statement/Yageo-company-3.pdf?src-supplier=Compliance+Statements; ComponentLink4Description: http://sigma.octopart.com:80/rohs_statement/Yageo-company-2.pdf?src-supplier=Compliance+Statements; ComponentLink4URL: http://sigma.octopart.com:80/rohs_statement/Yageo-company-2.pdf?src-supplier=Compliance+Statements; Composition: Thick Film; Contact Plating: Tin; Depth: 1.25mm; Height: 500µm; Length: 2mm; Library Name: ISL6236A_DEMO.SchLib; Lifecycle Status: Production; Manufacturer Part Number: RC0805FR-101RL; Max Operating Temperature: 155°C; Military Standard: Not; Min Operating Temperature: -55°C; Mounting Technology: Surface Mount; Number of Terminals: 2; Pricing: 1=0.1, 10=0.012, 50=0.012, 100=0.011, 1000=0.011, 10000=0.007 (USD); Radiation Hardening: No; REACH SVHC: Yes; RoHS: Compliant; Stock: 23078; Supplier: Mouser; Supplier Part Number: 603-RC0805FR-101RL; Tolerance: 1%; Width: 1.25mm; Working Voltage: 150V
R6 R7 R9 R10 = AF0805FR-07200KL: Anti-Sulfurated Chip Resistor Thick Film 0805 200kOhm 1% Paper T/R; Manufacturer: Yageo Group; Max Power Dissipation: 125mW; Temperature Coefficient: 100ppm/°C; Value: 200kΩ; Voltage Rating: 150V; Case/Package: 0805; Category: Chip SMD Resistors; China RoHS: Non-Compliant; Component Kind: Standard; ComponentLink1Description: https://datasheet.octopart.com:443/AF0805FR-07200KL-Yageo-datasheet-182189395.pdf?src-supplier=IHS; ComponentLink1URL: https://datasheet.octopart.com:443/AF0805FR-07200KL-Yageo-datasheet-182189395.pdf?src-supplier=IHS; ComponentLink2Description: https://datasheet.octopart.com:443/AF0805FR-07200KL-Yageo-datasheet-153645569.pdf?src-supplier=Upverter; ComponentLink2URL: https://datasheet.octopart.com:443/AF0805FR-07200KL-Yageo-datasheet-153645569.pdf?src-supplier=Upverter; ComponentLink3Description: https://sigma.octopart.com:443/138820526/technical_drawing/Yageo-AF0805FR-07200KL.pdf?src-supplier=Upverter; ComponentLink3URL: https://sigma.octopart.com:443/138820526/technical_drawing/Yageo-AF0805FR-07200KL.pdf?src-supplier=Upverter; ComponentLink4Description: https://datasheet.octopart.com:443/AF0805FR-07200KL-Yageo-datasheet-164730775.pdf?src-supplier=Future+Electronics; ComponentLink4URL: https://datasheet.octopart.com:443/AF0805FR-07200KL-Yageo-datasheet-164730775.pdf?src-supplier=Future+Electronics; ComponentLink5Description: https://datasheet.octopart.com:443/AF0805FR-07200KL-Yageo-datasheet-137481464.pdf?src-supplier=Future+Electronics; ComponentLink5URL: https://datasheet.octopart.com:443/AF0805FR-07200KL-Yageo-datasheet-137481464.pdf?src-supplier=Future+Electronics; ComponentLink6Description: https://datasheet.octopart.com/AF0805FR-07200KL-Yageo-datasheet-68296209.pdf; ComponentLink6URL: https://datasheet.octopart.com/AF0805FR-07200KL-Yageo-datasheet-68296209.pdf; ComponentLink7Description: http://sigma.octopart.com:80/rohs_statement/Yageo-company-2.pdf?src-supplier=Compliance+Statements; ComponentLink7URL: http://sigma.octopart.com:80/rohs_statement/Yageo-company-2.pdf?src-supplier=Compliance+Statements; ComponentLink8Description: http://sigma.octopart.com:80/conflict_mineral_statement/Yageo-company-3.pdf?src-supplier=Compliance+Statements; ComponentLink8URL: http://sigma.octopart.com:80/conflict_mineral_statement/Yageo-company-3.pdf?src-supplier=Compliance+Statements; Composition: Thick Film; Features: Anti-Sulfur, Moisture Resistant; Height: 500µm; Length: 2.0066mm; Library Name: ISL6236A_DEMO.SchLib; Lifecycle Status: Production; Manufacturer Part Number: AF0805FR-07200KL; Max Operating Temperature: 155°C; Min Operating Temperature: -55°C; Mounting Technology: Surface Mount; Number of Terminals: 2; Number of Terminations: 2; Packaging: Tape & Reel; Power Dissipation: 125mW; Power Rating: 125mW; Pricing: 1=0.11, 10=0.037, 50=0.037, 100=0.028, 1000=0.021, 10000=0.013 (USD); REACH SVHC: Yes; RoHS: Compliant; Stock: 8209; Supplier: Mouser; Supplier Part Number: 603-AF0805FR-07200KL; Tolerance: 1%; Width: 1.25mm; Working Voltage: 150V
R8 R11 = SFR10EZPF1002: Res Thick Film 0805 10K Ohm 1% 0.125W(1/8W) ±100ppm/C Sulfur Resistant Pad SMD T/R Automotive; Manufacturer: ROHM; Power Dissipation: 125mW; Temperature Coefficient: 100ppm/°C; Value: 10kΩ; Working Voltage: 150V; Category: Chip SMD Resistors; Component Kind: Standard; ComponentLink1Description: https://datasheet.octopart.com:443/SFR10EZPF1002-ROHM-datasheet-182147697.pdf?src-supplier=IHS; ComponentLink1URL: https://datasheet.octopart.com:443/SFR10EZPF1002-ROHM-datasheet-182147697.pdf?src-supplier=IHS; Height: 550µm; Library Name: ISL6236A_DEMO.SchLib; Lifecycle Status: Production; Manufacturer Part Number: SFR10EZPF1002; Max Operating Temperature: 155°C; Min Operating Temperature: -55°C; Mounting Technology: Surface Mount; Number of Terminals: 2; Pricing: 1=0.17, 10=0.055, 50=0.055, 100=0.035, 1000=0.031, 10000=0.025 (USD); REACH SVHC: Yes; RoHS: Compliant; Stock: 5513; Supplier: Mouser; Supplier Part Number: 755-SFR10EZPF1002; Tolerance: 1%; Width: 1.25mm
R12 R14 R16-R18 = RES: Component Kind: Standard; Library Name: ISL6236A_DEMO.SchLib
